# Altium schematic sheet FT234XD-R.SchDoc
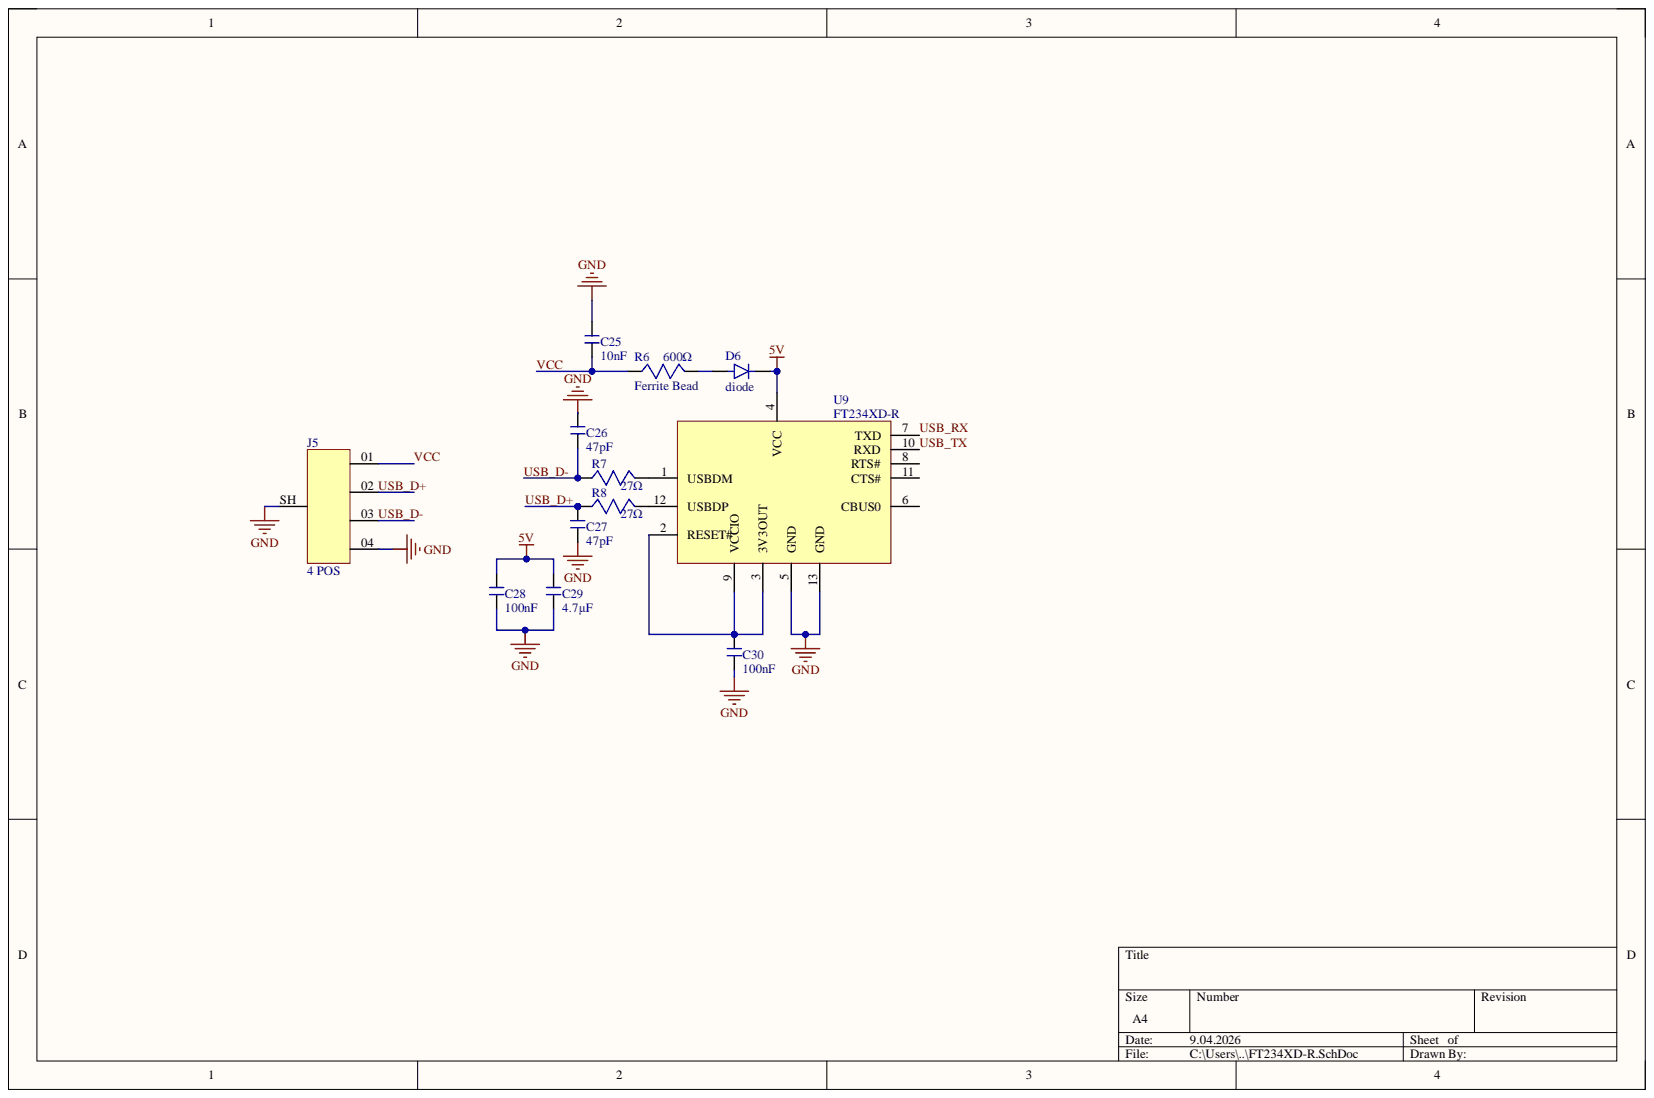
[diagram p0: FT234XD-R.SchDoc]

## Parts
C25  value 10nF  [402 capacitor - duplicate]  (hidden comment: 0402 capacitor)
C26  value 47pF  [402 capacitor - duplicate]  (hidden comment: 0402 capacitor)
C27  value 47pF  [402 capacitor - duplicate]  (hidden comment: 0402 capacitor)
C28  value 100nF  [402 capacitor - duplicate]  (hidden comment: 0402 capacitor)
C29  value 4.7µF  [603 CAPACITOR]  (hidden comment: 0603 CAPACİTOR)
C30  value 100nF  [402 capacitor - duplicate]  (hidden comment: 0402 capacitor)
D6  diode  [SS14]
J5  4 POS  [4 PIN USB]
R6  Ferrite Bead  value 600Ω  [603 resistor]
R7  value 27Ω  [402 RESISTOR]  (hidden comment: 0402. RESİSTOR)
R8  value 27Ω  [402 RESISTOR]  (hidden comment: 0402. RESİSTOR)
U9  FT234XD-R  [FT234XD-R]

## Nets
5V: C28.2 C29.2 D6.2 U9.4(VCC)
GND: C25.2 C26.2 C27.1 C28.1 C29.1 C30.1 J5.04 J5.SH U9.5(GND) U9.13(GND)
USB_D+: C27.2 J5.02 R8.1
USB_D-: C26.1 J5.03 R7.1
USB_RX: U9.7(TXD)
USB_TX: U9.10(RXD)
VCC: C25.1 J5.01 R6.1
NetC30_2: C30.2 U9.2(RESET#) U9.3(3V3OUT) U9.9(VCCIO)
NetD6_1: D6.1 R6.2
NetR7_2: R7.2 U9.1(USBDM)
NetR8_2: R8.2 U9.12(USBDP)

Not connected: U9 6(CBUS0) 8(RTS#) 11(CTS#)

## Library data of the parts
C25 = CAP SMD 402: MPN: C0402C103K5RECAUTO; Component Kind: Standard; Description: CAP SMD 402; Library Name: Schlib1.SchLib; Package: 0402
C26 C27 = CAP SMD 402: MPN: GCM1555C1H470JA16D; Component Kind: Standard; Description: CAP SMD 402; Library Name: Schlib1.SchLib; Package: 0402
C28 C30 = CAP SMD 402: MPN: CL05A104KA5NNNC; Component Kind: Standard; Description: CAP SMD 402; Library Name: Schlib1.SchLib; Package: 0402
C29 = CAP SMD 0603: MPN: GRM188R61E475KE11D; Pacage: 0603; Component Kind: Standard; Description: CAP SMD 0603; Library Name: Schlib1.SchLib
D6 = SS14: DIODE SCHOTTKY 40V 1A DO214AC; Component Kind: Standard; Library Name: Schlib1.SchLib; MPN: SS14
J5 = 87583-3010RPALF: CONN RCPT USB2.0 TYPEA 4P SMD RA; Component Kind: Standard; Library Name: Schlib1.SchLib; MPN: 87583-3010RPALF
R6 = RES SMD 0603: MPN: MI0603J601R-10; Component Kind: Standard; Description: RES SMD 0603; Library Name: Schlib1.SchLib; Package: 0603
R7 R8 = RES SMD 0402: MPN: RC0402FR-0727RL; Component Kind: Standard; Description: RES SMD 0402; Library Name: Schlib1.SchLib; Package: 0402
U9 = FT234XD-R: IC USB SERIAL BASIC UART 12DFN (0V - 3.3V, 27Ω - 90Ω); Component Kind: Standard; Library Name: Schlib1.SchLib; MPN: FT234XD-R
